# Altium schematic sheet 06_Ethernet.SchDoc
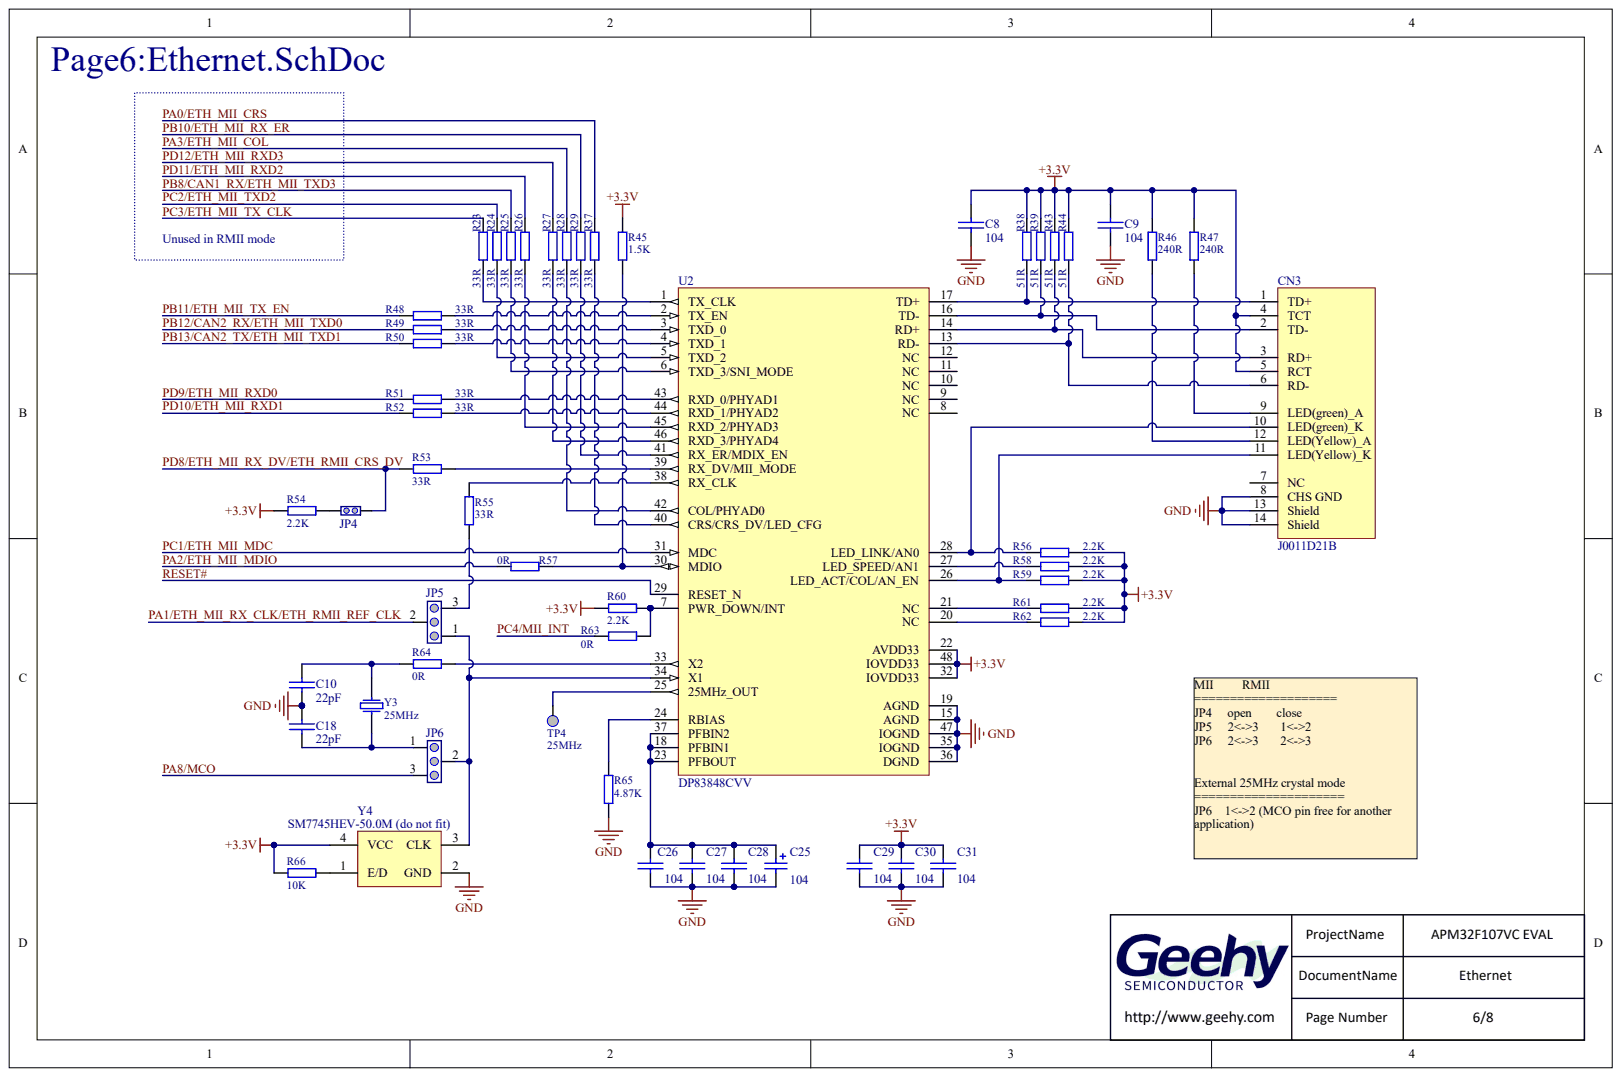
[diagram p0: 06_Ethernet.SchDoc]

## Parts
C8  104
C9  104
C10  22pF
C18  22pF
C25  104
C26  104
C27  104
C28  104
C29  104
C30  104
C31  104
CN3  J0011D21B
JP4  (no value)
JP5  (no value)
JP6  (no value)
R23  33R
R24  33R
R25  33R
R26  33R
R27  33R
R28  33R
R29  33R
R37  33R
R38  51R
R39  51R
R43  51R
R44  51R
R45  1.5K
R46  240R
R47  240R
R48  33R
R49  33R
R50  33R
R51  33R
R52  33R
R53  33R
R54  2.2K
R55  33R
R56  2.2K
R57  0R
R58  2.2K
R59  2.2K
R60  2.2K
R61  2.2K
R62  2.2K
R63  0R
R64  0R
R65  4.87K
R66  10K
TP4  25MHz
U2  DP83848CVV
Y3  25MHz
Y4  SM7745HEV-50.0M (do not fit)

## Nets
+3.3V: C8.2 C9.2 C29.1 C30.2 C31.2 CN3.4(TCT) CN3.5(RCT) R38.2 R39.2 R43.2 R44.2 R45.2 R46.2 R47.2 R54.2 R56.1 R58.1 R59.1 R60.2 R61.1 R62.1 R66.1 U2.22(AVDD33) U2.32(IOVDD33) U2.48(IOVDD33) Y4.4(VCC)
GND: C8.1 C9.1 C10.1 C18.2 C25.2 C26.2 C27.1 C28.1 C29.2 C30.1 C31.1 CN3.8(CHS_GND) CN3.13(Shield) CN3.14(Shield) R65.2 U2.15(AGND) U2.19(AGND) U2.35(IOGND) U2.36(DGND) U2.47(IOGND) Y4.2(GND)
PA0/ETH_MII_CRS: R37.2
PA1/ETH_MII_RX_CLK/ETH_RMII_REF_CLK: JP5.2
PA2/ETH_MII_MDIO: R57.2
PA3/ETH_MII_COL: R28.2
PA8/MCO: JP6.3
PB8/CAN1_RX/ETH_MII_TXD3: R25.2
PB10/ETH_MII_RX_ER: R29.2
PB11/ETH_MII_TX_EN: R48.2
PB12/CAN2_RX/ETH_MII_TXD0: R49.2
PB13/CAN2_TX/ETH_MII_TXD1: R50.2
PC1/ETH_MII_MDC: U2.31(MDC)
PC2/ETH_MII_TXD2: R24.2
PC3/ETH_MII_TX_CLK: R23.2
PC4/MII_INT: R63.2
PD8/ETH_MII_RX_DV/ETH_RMII_CRS_DV: JP4.2 R53.2
PD9/ETH_MII_RXD0: R51.2
PD10/ETH_MII_RXD1: R52.2
PD11/ETH_MII_RXD2: R26.2
PD12/ETH_MII_RXD3: R27.2
RESET#: U2.29(RESET_N)
NetC10_2: C10.2 R64.2 Y3.1
NetC18_1: C18.1 JP6.1 Y3.2
NetC25_1: C25.1 C26.1 C27.2 C28.2 U2.18(PFBIN1) U2.23(PFBOUT) U2.37(PFBIN2)
NetCN3_1: CN3.1(TD+) R38.1 U2.17(TD+)
NetCN3_2: CN3.2(TD-) R39.1 U2.16(TD-)
NetCN3_3: CN3.3(RD+) R43.1 U2.14(RD+)
NetCN3_6: CN3.6(RD-) R44.1 U2.13(RD-)
NetCN3_9: CN3.9(LED(green)_A) R47.1
NetCN3_10: CN3.10(LED(green)_K) R56.2 U2.28(LED_LINK/AN0)
NetCN3_11: CN3.11(LED(Yellow)_K) R59.2 U2.26(LED_ACT/COL/AN_EN)
NetCN3_12: CN3.12(LED(Yellow)_A) R46.1
NetJP4_1: JP4.1 R54.1
NetJP5_1: JP5.1 JP6.2 U2.34(X1) Y4.3(CLK)
NetJP5_3: JP5.3 R55.1
NetR23_1: R23.1 U2.1(TX_CLK)
NetR24_1: R24.1 U2.5(TXD_2)
NetR25_1: R25.1 U2.6(TXD_3/SNI_MODE)
NetR26_1: R26.1 U2.45(RXD_2/PHYAD3)
NetR27_1: R27.1 U2.46(RXD_3/PHYAD4)
NetR28_1: R28.1 U2.42(COL/PHYAD0)
NetR29_1: R29.1 U2.41(RX_ER/MDIX_EN)
NetR37_1: R37.1 U2.40(CRS/CRS_DV/LED_CFG)
NetR45_1: R45.1 R57.1 U2.30(MDIO)
NetR48_1: R48.1 U2.2(TX_EN)
NetR49_1: R49.1 U2.3(TXD_0)
NetR50_1: R50.1 U2.4(TXD_1)
NetR51_1: R51.1 U2.43(RXD_0/PHYAD1)
NetR52_1: R52.1 U2.44(RXD_1/PHYAD2)
NetR53_1: R53.1 U2.39(RX_DV/MII_MODE)
NetR55_2: R55.2 U2.38(RX_CLK)
NetR58_2: R58.2 U2.27(LED_SPEED/AN1)
NetR60_1: R60.1 R63.1 U2.7(PWR_DOWN/INT)
NetR61_2: R61.2 U2.21(NC)
NetR62_2: R62.2 U2.20(NC)
NetR64_1: R64.1 U2.33(X2)
NetR65_1: R65.1 U2.24(RBIAS)
NetR66_2: R66.2 Y4.1(E/D)
NetTP4_1: TP4.1 U2.25(25MHz_OUT)

Not connected: CN3 7(NC); U2 8(NC) 9(NC) 10(NC) 11(NC) 12(NC)

## Library data of the parts
C25 = (no symbol name): Value: 10uF; Published: 8-Jun-2000; Revision: July-2002: Re-released for DXP Platform.; Publisher: Altium Limited
